# Altium schematic sheet sch-split-flap-ctl.SchDoc
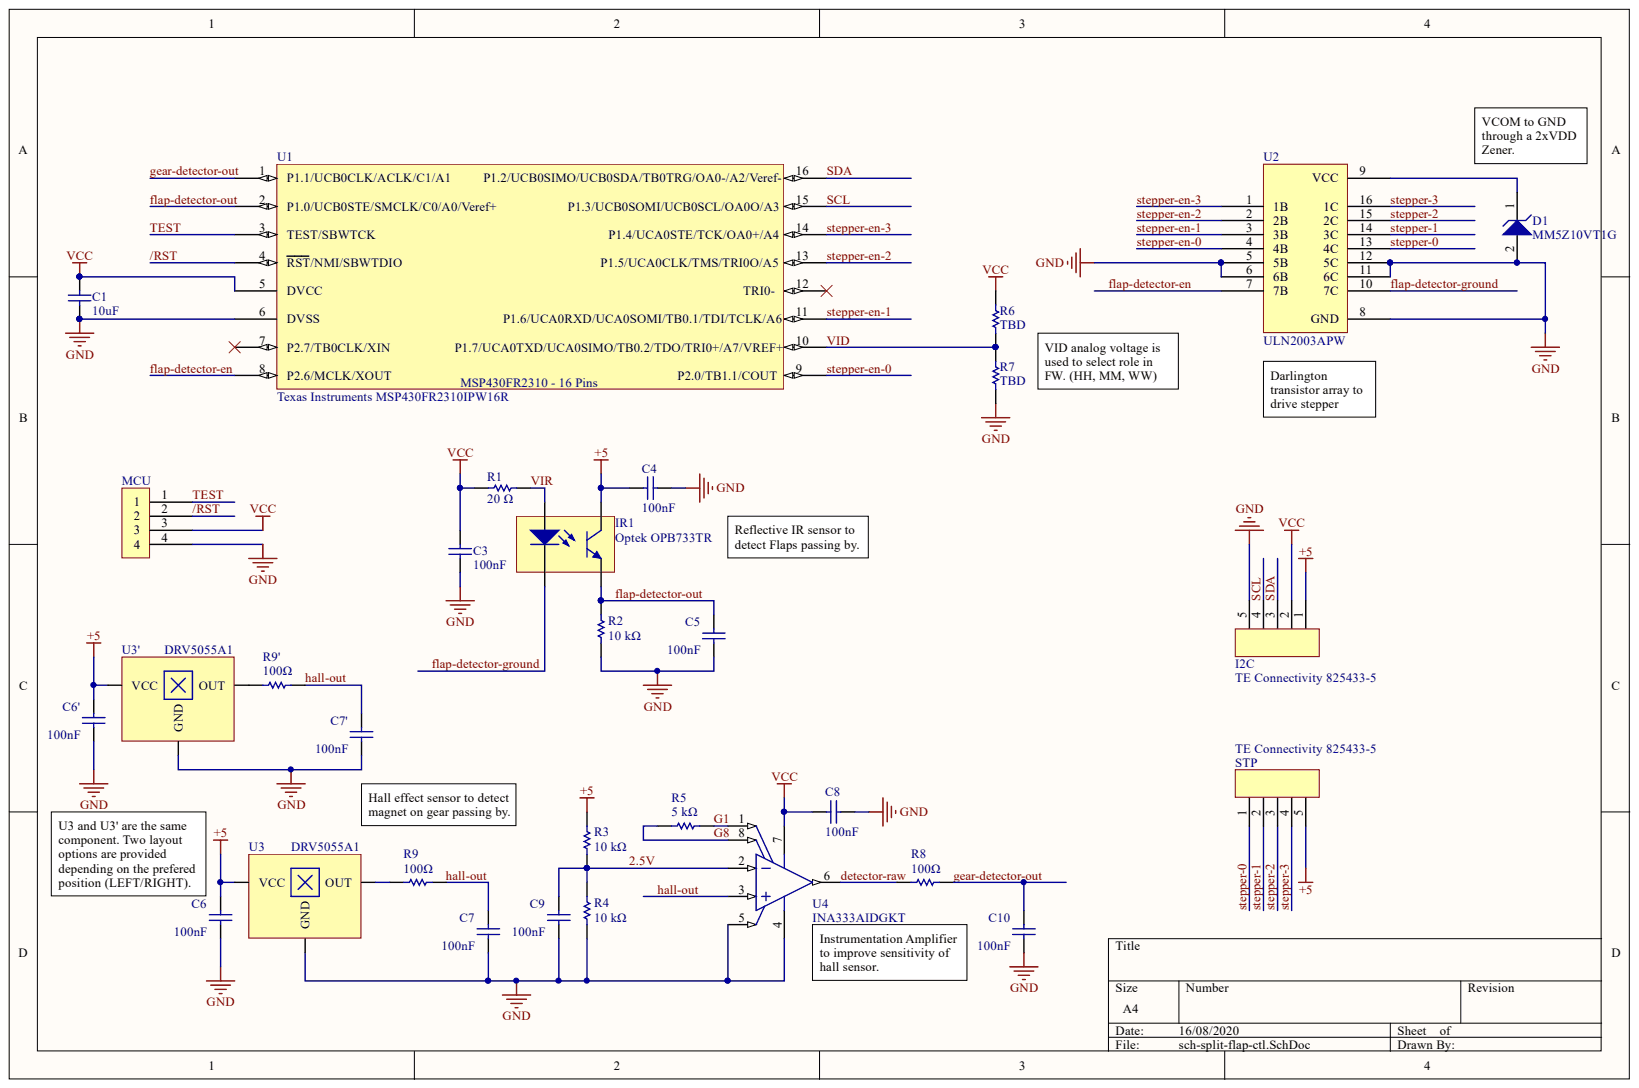
[diagram p0: sch-split-flap-ctl.SchDoc]

## Parts
C1  (no value)  [PCB-2mzf6e7t17kwno4sy370-1]
C3  (no value)  [PCB-2mzf6e7t17kwno4sy370-1]
C4  (no value)  [PCB-2mzf6e7t17kwno4sy370-1]
C5  (no value)  [PCB-2mzf6e7t17kwno4sy370-1]
C6  (no value)  [PCB-2mzf6e7t17kwno4sy370-1]
C6'  (no value)  [PCB-2mzf6e7t17kwno4sy370-1]
C7  (no value)  [PCB-2mzf6e7t17kwno4sy370-1]
C7'  (no value)  [PCB-2mzf6e7t17kwno4sy370-1]
C8  (no value)  [PCB-2mzf6e7t17kwno4sy370-1]
C9  (no value)  [PCB-2mzf6e7t17kwno4sy370-1]
C10  (no value)  [PCB-2mzf6e7t17kwno4sy370-1]
D1  MM5Z10VT1G  [ONSC-SOD-523-2-502-01_V]
I2C  TE Connectivity 825433-5  [PCB-xti7u9j93a3qzo3mq4li-1]
IR1  Optek OPB733TR  [PCB-s0bk49ykzd3nyn6ocxo8-1]
MCU  TE Connectivity / AMP 5-520314-4  [PCB-2e32bpzlb7s96t5zrzh4-1]  (value not drawn)
R1  20 Ω  [PCB-2ox8asr4tk2mzt0c5jnj-1]
R2  10 kΩ  [PCB-2ox8asr4tk2mzt0c5jnj-1]
R3  10 kΩ  [PCB-2ox8asr4tk2mzt0c5jnj-1]
R4  10 kΩ  [PCB-2ox8asr4tk2mzt0c5jnj-1]
R5  5 kΩ  [PCB-2ox8asr4tk2mzt0c5jnj-1]
R6  TBD  [PCB-2ox8asr4tk2mzt0c5jnj-1]
R7  TBD  [PCB-2ox8asr4tk2mzt0c5jnj-1]
R8  100Ω  [PCB-2ox8asr4tk2mzt0c5jnj-1]
R9  100Ω  [PCB-2ox8asr4tk2mzt0c5jnj-1]
R9'  100Ω  [PCB-2ox8asr4tk2mzt0c5jnj-1]
STP  TE Connectivity 825433-5  [PCB-xti7u9j93a3qzo3mq4li-1]
U1  Texas Instruments MSP430FR2310IPW16R  Manufacturer Part Number: MSP430FR2310IPW16R  [TSSOP16]
U2  ULN2003APW  [PCB-o079r3vxg80uk6bdh2cv-1]
U3  DRV5055A1  [PCB-vzxvail1iw7qqvckidk2-1]
U3'  DRV5055A1  [PCB-vzxvail1iw7qqvckidk2-1]
U4  INA333AIDGKT  [TI-DGK8_M]

## Nets
+5: C4.2 C6.2 C6'.2 I2C.1 IR1.3 R3.2 STP.5 U3.1 U3'.1
GND: C1.1 C3.1 C4.1 C5.1 C6.1 C6'.1 C7.1 C7'.1 C8.1 C9.1 C10.1 D1.2 I2C.5 MCU.4 R2.1 R4.1 R7.1 U1.6(DVSS) U2.5(5B) U2.6(6B) U2.8(GND) U2.11(6C) U2.12(5C) U3.3 U3'.3 U4.4 U4.5
VCC: C1.2 C3.2 C8.2 I2C.2 MCU.3 R1.1 R6.2 U1.5(DVCC) U4.7
2.5V: C9.2 R3.1 R4.2 U4.2
/RST: MCU.2 U1.4(RST/NMI/SBWTDIO)
DETECTOR-RAW: R8.1 U4.6
FLAP-DETECTOR-EN: U1.8(P2.6/MCLK/XOUT) U2.7(7B)
FLAP-DETECTOR-GROUND: IR1.1 U2.10(7C)
FLAP-DETECTOR-OUT: C5.2 IR1.2 R2.2 U1.2(P1.0/UCB0STE/SMCLK/C0/A0/Veref+)
G1: R5.2 U4.1
G8: R5.1 U4.8
GEAR-DETECTOR-OUT: C10.2 R8.2 U1.1(P1.1/UCB0CLK/ACLK/C1/A1)
HALL-OUT: C7.2 C7'.2 R9.2 R9'.2 U4.3
SCL: I2C.4 U1.15(P1.3/UCB0SOMI/UCB0SCL/OA0O/A3)
SDA: I2C.3 U1.16(P1.2/UCB0SIMO/UCB0SDA/TB0TRG/OA0-/A2/Veref-)
STEPPER-0: STP.1 U2.13(4C)
STEPPER-1: STP.2 U2.14(3C)
STEPPER-2: STP.3 U2.15(2C)
STEPPER-3: STP.4 U2.16(1C)
STEPPER-EN-0: U1.9(P2.0/TB1.1/COUT) U2.4(4B)
STEPPER-EN-1: U1.11(P1.6/UCA0RXD/UCA0SOMI/TB0.1/TDI/TCLK/A6) U2.3(3B)
STEPPER-EN-2: U1.13(P1.5/UCA0CLK/TMS/TRI0O/A5) U2.2(2B)
STEPPER-EN-3: U1.14(P1.4/UCA0STE/TCK/OA0+/A4) U2.1(1B)
TEST: MCU.1 U1.3(TEST/SBWTCK)
VID: R6.1 R7.2 U1.10(P1.7/UCA0TXD/UCA0SIMO/TB0.2/TDO/TRI0+/A7/VREF+)
VIR: IR1.4 R1.2
NetD1_1: D1.1 U2.9(VCC)
NetR9'_1: R9'.1 U3'.2
NetR9_1: R9.1 U3.2

Not connected: U1 7(P2.7/TB0CLK/XIN) 12(TRI0-)

## Library data of the parts
C1 C3-C6 C6' C7 C7' C8-C10 = CMP-1af8d38ac64bc53f-6: CL10 Series 0.1 uF 50 V ±10% Tolerance X7R SMT Multilayer Ceramic Capacitor; Component Kind: Standard; Library Name: FavoritesLibrary
  C1: : 10uF
  C3-C6 C6' C7 C7' C8-C10: : 100nF
D1 = CMP-807341-2: Zener Voltage Regulator, 500 mW, 2-Pin SOD-523, Pb-Free, Tape and Reel; Lead-Free Status: Lead Free; Material: Plastic; Power Rating: 0.1 W; Voltage Rating (DC): 10.0 V; Case/Package: SOD-523; Component Kind: Standard; Library Name: CommunityVault; Lifecycle Status: Active; Manufacturer Part Number: MM5Z10VT1G; Mounting Style: Surface Mount; Number of Pins: 2; OctopartID: ebf822f7c352343f; Octopart Url: https://octopart.com/mm5z10vt1g-on+semiconductor-331212; Packaging: Cut Tape (CT); REACH SVHC Compliance: No SVHC; RoHS: Compliant
I2C STP = CMP-a17c6af386817ebb-12: Conn Unshrouded Header HDR 5 POS 2.54mm Solder ST Thru-Hole; Component Kind: Standard; Library Name: CommunityVault
IR1 = CMP-ac216666c19173e6-1: 890 nm 50 mA 30 V 10.2 to 25.4 mm Range SMT Reflective Object Sensor; Forward Voltage: 1.70 V; Lead-Free Status: Lead Free; Output Current: 20.0 mA; Output Voltage: 30.0 V (max); Component Kind: Standard; Library Name: FavoritesLibrary; Manufacturer Part Number: OPB733TR; Mounting Style: Surface Mount; Mouser: 828-OPB733TR; OctopartID: ac216666c19173e6; Octopart URL: https://octopart.com/opb733tr-optek-55396566; Operating Temperature: 85.0 °C (max); Packaging: Reel; RoHS: Compliant
MCU = CMP-5c1097d27ab083d7-7: Wire-To-Board Connector, AMPMODU Mod II Series, Through Hole, Header, 4, 2.54 mm; Current Rating: 3.00 A; ELV Compliant: Compliant; Gender: Male; Lead-Free Status: Lead Free; Number of Contacts: 4; Pin Pitch: 2.54 mm; Color: Green; Component Kind: Standard; Contact Material: Phosphor Bronze; Contact Plating: Tin; Flammability Rating: UL 94 V-0; Library Name: CommunityVault; Lifecycle Status: Active; Mounting Style: PCB; OctopartID: 5c1097d27ab083d7; Octopart URL: http://octopart.com/826926-4-te+connectivity-46662598; Orientation: Vertical; REACH SVHC Compliance: No SVHC
R1-R9 R9' = CMP-9c8b5704b0ee434c-2: Surface Mount Chip Resistor, Thin Film, RT Series, 1 kohm, 100 mW, 1%, 75 V, 0603 [1608 Metric]; Manufacturer Part Number: RT0603FRE071KL; Case/Package (SI): 1608; Lead-Free Status: Lead Free; Power Rating: 100 mW; Resistance: 1.00 k?; Voltage Rating (DC): 75.0 V; Case/Package: 0603; Component Kind: Standard; Mounting Style: Surface Mount; Number of Pins: 2; OctopartID: 9c8b5704b0ee434c; Octopart URL: http://octopart.com/rt0603fre071kl-yageo-42273027; Packaging: Tape & Reel; REACH SVHC Compliance: No SVHC; Resistance Tolerance: ±1%; RoHS: Compliant; Size-Height: 450 µm; Size-Length: 1.60 mm; Size-Width: 800 µm
  R1 R5 R8 R9 R9': Library Name: CommunityVault
  R2-R4 R6 R7: Library Name: FavoritesLibrary
U1 = CMP-7ebab38f542ba17d-1: 16 MHz Ultra-Low-Power Microcontroller - 2 KB FRAM, 1 KB SRAM, 16 IO, 8ch 10-bit ADC, OpAmp, TIA 16-TSSOP -40 to 85; Clock Speed: 16.0 MHz; Lead-Free Status: Contains Lead; Number of UARTs: 1; RAM Memory Size: 3072 B; Case/Package: TSSOP; Component Kind: Standard; Frequency: 16.0 MHz; Library Name: FavoritesLibrary; Lifecycle Status: Pre-Release; Mouser: 595-P430FR2310IPW16R; Number of Pins: 16; OctopartID: 7ebab38f542ba17d; Octopart URL: https://octopart.com/msp430fr2310ipw16r-texas+instruments-71241719; Operating Temperature: -40.0 °C to 85.0 °C; RoHS: Compliant
U2 = ULN2003APW: Darlington Transistor Array; Lead-Free Status: Lead Free; Polarity: NPN; Case/Package: TSSOP; Component Kind: Standard; Library Name: Octopart library; Lifecycle Status: Active; Mounting Style: Surface Mount; Mouser: 595-ULN2003APWR; Number of Pins: 16; OctopartID: 83444a22d3b75c83; Octopart URL: http://octopart.com/uln2003apw-texas+instruments-7107989; Packaging: Tube; REACH SVHC Compliance: No SVHC; RoHS: Compliant
U3 U3' = CMP-924e5e16bdb64d89-1: Ratiometric linear hall effect sensor with analog output 3-SOT-23 -40 to 125; Manufacturer Part Number: DRV5055A1QDBZT; Output Current: 1.00 mA; Supply Voltage (DC): 3.00 V (min), 5.50 V (max); Case/Package: SOT-23; Component Kind: Standard; Library Name: FavoritesLibrary; Lifecycle Status: Pre-Release; Number of Pins: 3; OctopartID: 924e5e16bdb64d89; Octopart URL: https://octopart.com/drv5055a1qdbzt-texas+instruments-89262750; Operating Temperature: -40.0 °C (min); Packaging: Cut Tape (CT); RoHS: Compliant
  U3: Mouser: 595-DRV5055A1QDBZR
U4 = CMP-1154636-2: Low Power, Precision Instrumentation Amplifier, -40 to 125 degC, 8-pin SOP (DGK8), Green (RoHS & no Sb/Br); Bandwidth: 150000.0 Hz; Lead-Free Status: Lead Free; Number of Channels: 1; Quiescent Current: 5e-05 A; Slew Rate: 0.16 V/μs; Component Kind: Standard; Library Name: CommunityVault; Lifecycle Status: Active; Manufacturer Part Number: INA333AIDGKT; Mounting Style: Surface Mount; Number of Pins: 8; OctopartID: 43678a1382239ca0; Octopart Url: https://octopart.com/ina333aidgkt-texas+instruments-8315938; Packaging: Tape & Reel (TR); REACH SVHC Compliance: No SVHC; RoHS: Compliant; Supply Current: 5e-05 A
